# Altium schematic sheet 15_HEATER.SchDoc(15_HEATER)
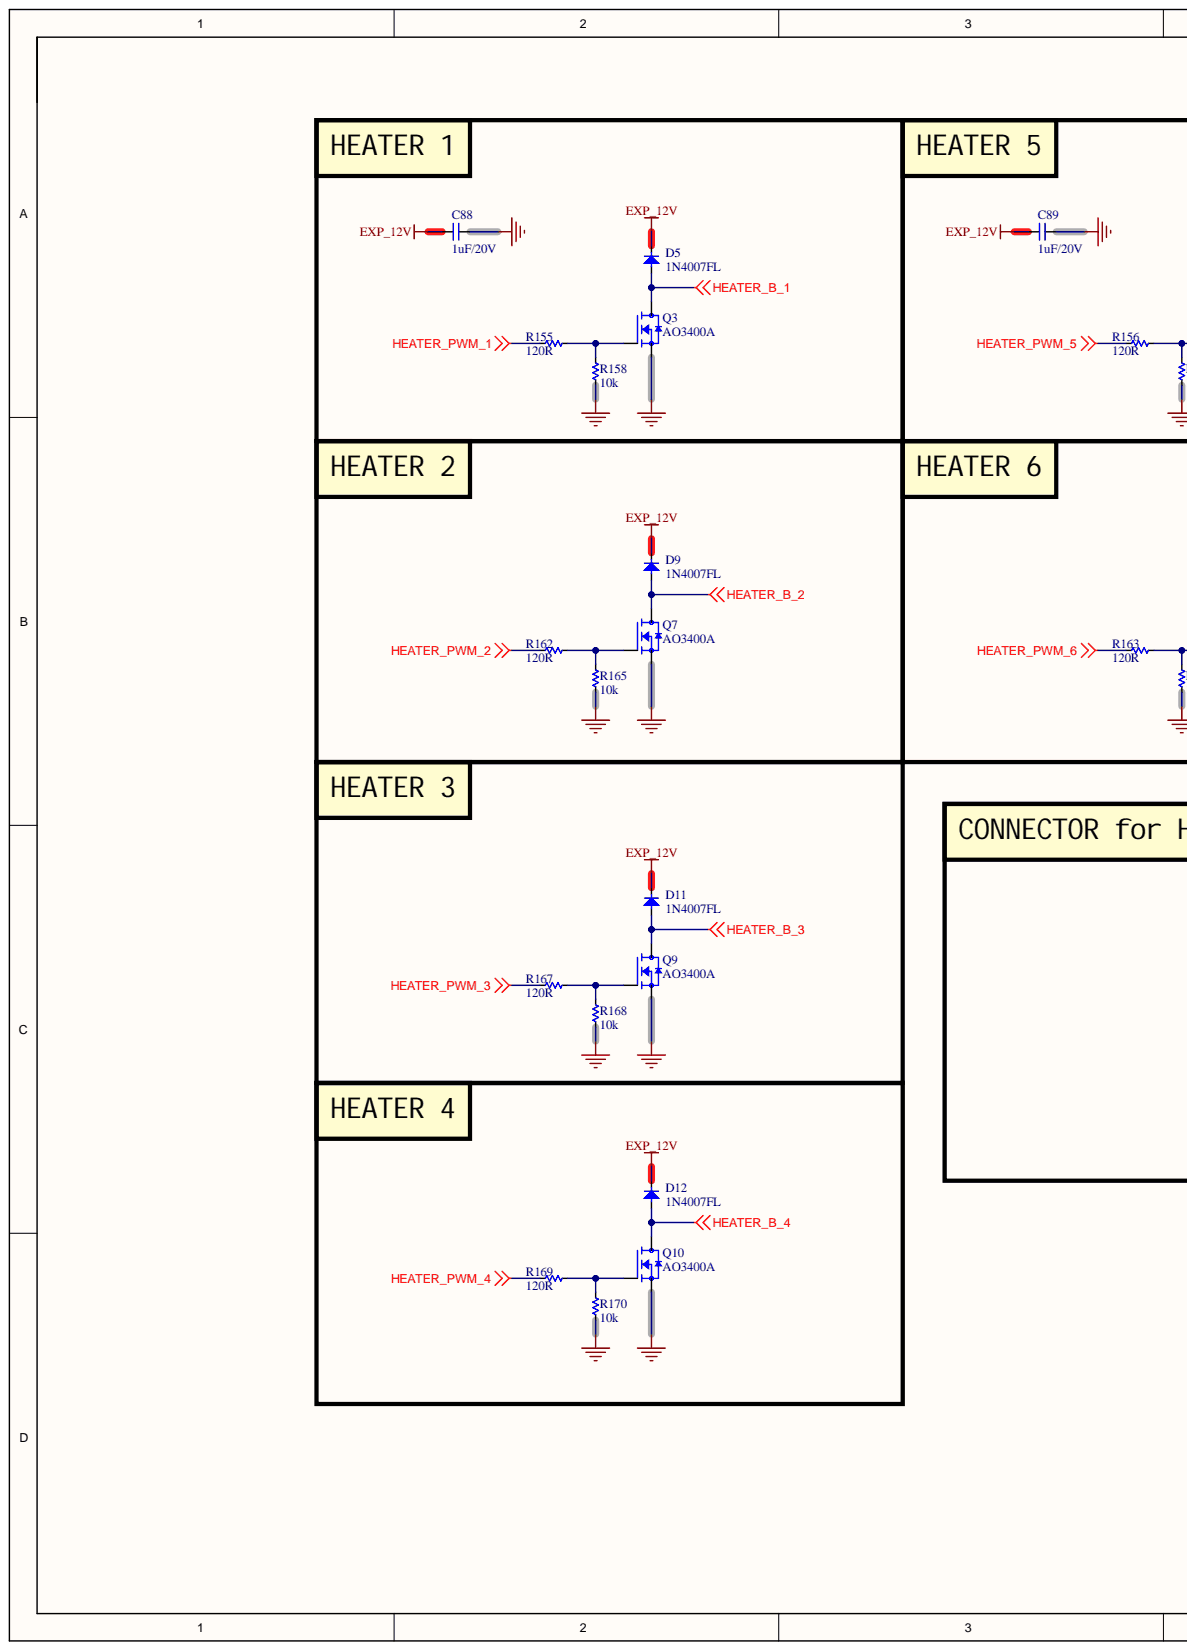
[diagram p0: 15_HEATER.SchDoc, left]
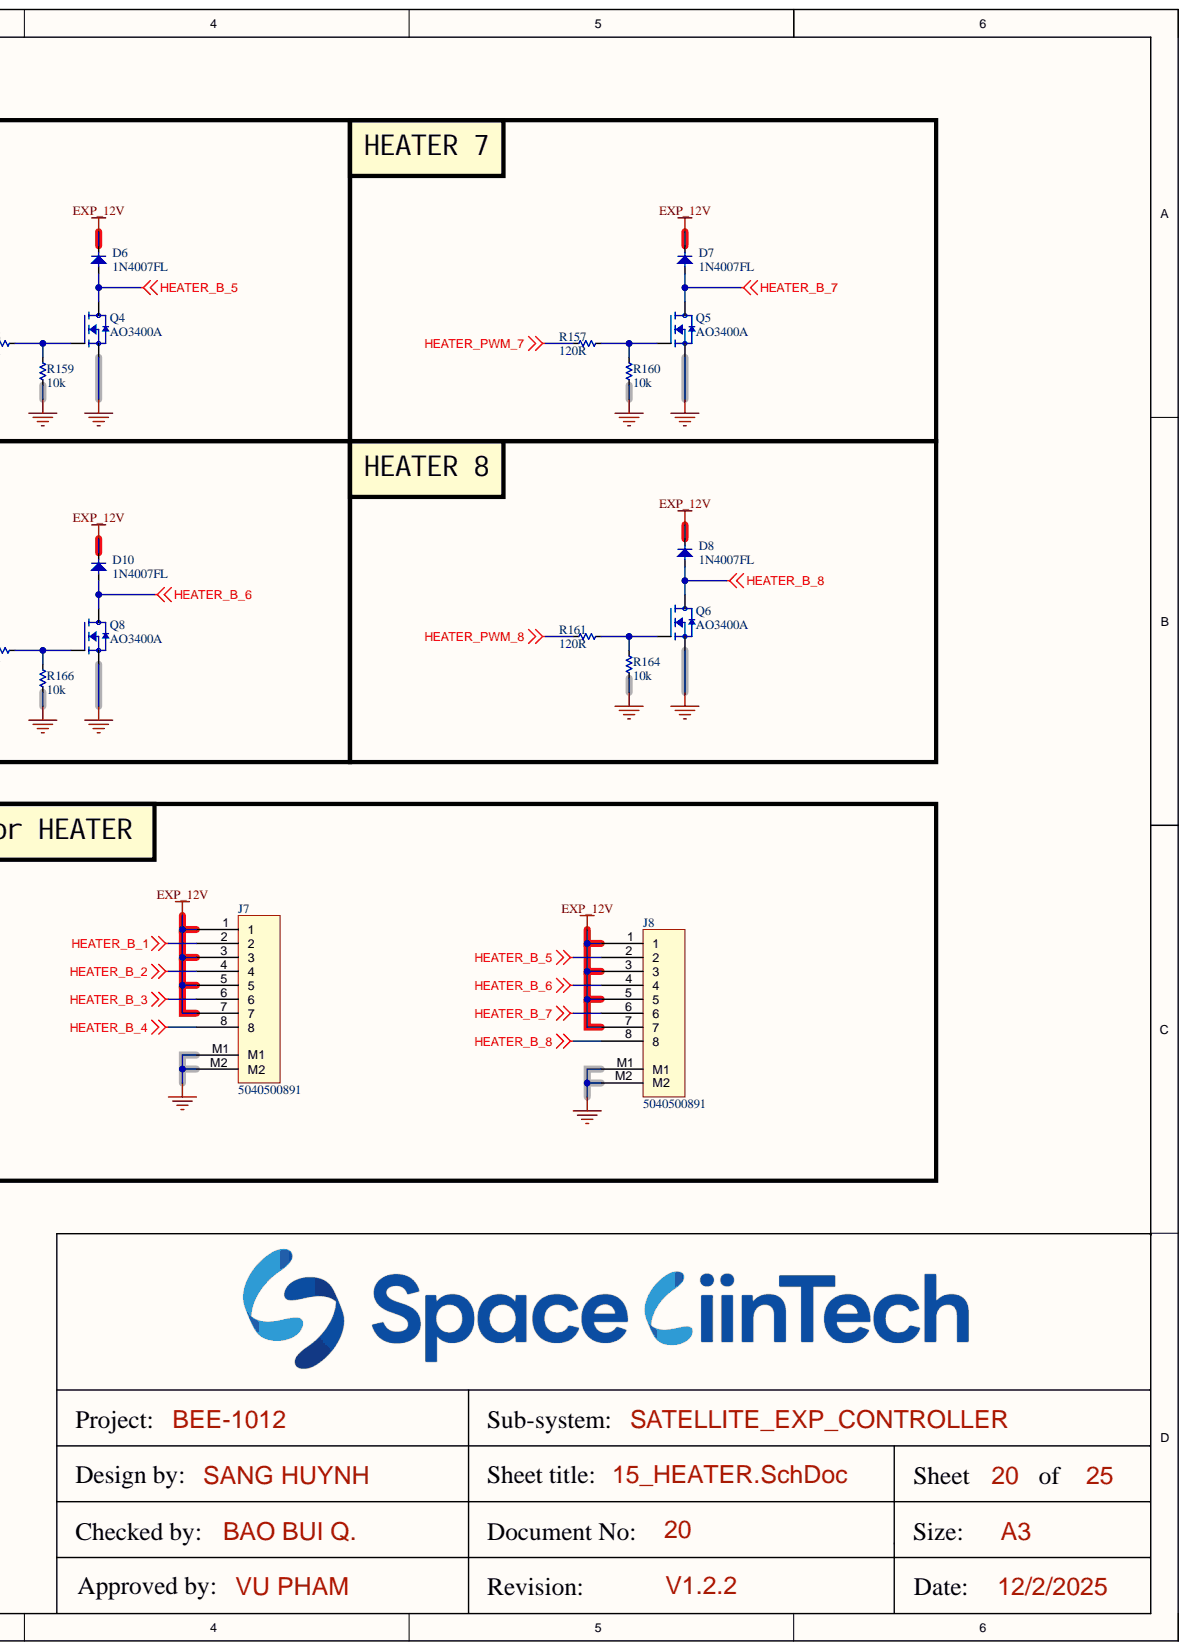
[diagram p1: 15_HEATER.SchDoc, right]

## Parts
C88  1uF/20V  [CAP_C_1005X60]
C89  1uF/20V  [CAP_C_1005X60]
D5  1N4007FL  [SOD3717X145N]
D6  1N4007FL  [SOD3717X145N]
D7  1N4007FL  [SOD3717X145N]
D8  1N4007FL  [SOD3717X145N]
D9  1N4007FL  [SOD3717X145N]
D10  1N4007FL  [SOD3717X145N]
D11  1N4007FL  [SOD3717X145N]
D12  1N4007FL  [SOD3717X145N]
J7  5040500891  [MOLEX_5040500891]
J8  5040500891  [MOLEX_5040500891]
Q3  AO3400A  [SOT95P240X120-3N]
Q4  AO3400A  [SOT95P240X120-3N]
Q5  AO3400A  [SOT95P240X120-3N]
Q6  AO3400A  [SOT95P240X120-3N]
Q7  AO3400A  [SOT95P240X120-3N]
Q8  AO3400A  [SOT95P240X120-3N]
Q9  AO3400A  [SOT95P240X120-3N]
Q10  AO3400A  [SOT95P240X120-3N]
R155  120R  [RES_C_1005X40]
R156  120R  [RES_C_1005X40]
R157  120R  [RES_C_1005X40]
R158  10k  [RES_C_1005X40]
R159  10k  [RES_C_1005X40]
R160  10k  [RES_C_1005X40]
R161  120R  [RES_C_1005X40]
R162  120R  [RES_C_1005X40]
R163  120R  [RES_C_1005X40]
R164  10k  [RES_C_1005X40]
R165  10k  [RES_C_1005X40]
R166  10k  [RES_C_1005X40]
R167  120R  [RES_C_1005X40]
R168  10k  [RES_C_1005X40]
R169  120R  [RES_C_1005X40]
R170  10k  [RES_C_1005X40]

## Nets
EXP_12V: C88.2 C89.2 D5.1 D6.1 D7.1 D8.1 D9.1 D10.1 D11.1 D12.1 J7.1 J7.3 J7.5 J7.7 J8.1 J8.3 J8.5 J8.7
GND: C88.1 C89.1 J7.M1 J7.M2 J8.M1 J8.M2 Q3.2 Q4.2 Q5.2 Q6.2 Q7.2 Q8.2 Q9.2 Q10.2 R158.1 R159.1 R160.1 R164.1 R165.1 R166.1 R168.1 R170.1
HEATER_B_1: D5.2 J7.2 Q3.3
HEATER_B_2: D9.2 J7.4 Q7.3
HEATER_B_3: D11.2 J7.6 Q9.3
HEATER_B_4: D12.2 J7.8 Q10.3
HEATER_B_5: D6.2 J8.2 Q4.3
HEATER_B_6: D10.2 J8.4 Q8.3
HEATER_B_7: D7.2 J8.6 Q5.3
HEATER_B_8: D8.2 J8.8 Q6.3
HEATER_PWM_1: R155.1
HEATER_PWM_2: R162.1
HEATER_PWM_3: R167.1
HEATER_PWM_4: R169.1
HEATER_PWM_5: R156.1
HEATER_PWM_6: R163.1
HEATER_PWM_7: R157.1
HEATER_PWM_8: R161.1
NetQ3_1: Q3.1 R155.2 R158.2
NetQ4_1: Q4.1 R156.2 R159.2
NetQ5_1: Q5.1 R157.2 R160.2
NetQ6_1: Q6.1 R161.2 R164.2
NetQ7_1: Q7.1 R162.2 R165.2
NetQ8_1: Q8.1 R163.2 R166.2
NetQ9_1: Q9.1 R167.2 R168.2
NetQ10_1: Q10.1 R169.2 R170.2

## Library data of the parts
C88 C89 = _CAP_C_2: CAP CHIP; Component Kind: Standard; Library Name: LABLIB.SchLib
D5-D12 = Diode SMD: Default Diode; Code - JEDEC: DO-214-AB; Value: 1N5007; Component Kind: Standard; Published: 8-Jun-2000; Revision: July-2002: Re-released for DXP Platform.; Library Name: LABLIB.SchLib; Publisher: Altium Limited
J7 J8 = Header 8x1_2M: Component Kind: Standard; Library Name: LABLIB.SchLib
Q3-Q10 = MOSFET_N: N-Channel 30 V 5.7A (Ta) 1.4W (Ta) Surface Mount SOT-23-3; MF: Alpha & Omega Semiconductor; Check_prices: https://www.snapeda.com/parts/AO3400A/Alpha/view-part/?ref=eda; Component Kind: Standard; Library Name: new_component.SchLib; MP: AO3400A; Package: SOT-23 Alpha &amp; Omega Semiconductor Inc.; SnapEDA_Link: https://www.snapeda.com/parts/AO3400A/Alpha/view-part/?ref=snap
R155-R170 = _RES_B_2: RES; Component Kind: Standard; Library Name: LABLIB.SchLib
